# Altium schematic sheet Sheet2.SchDoc
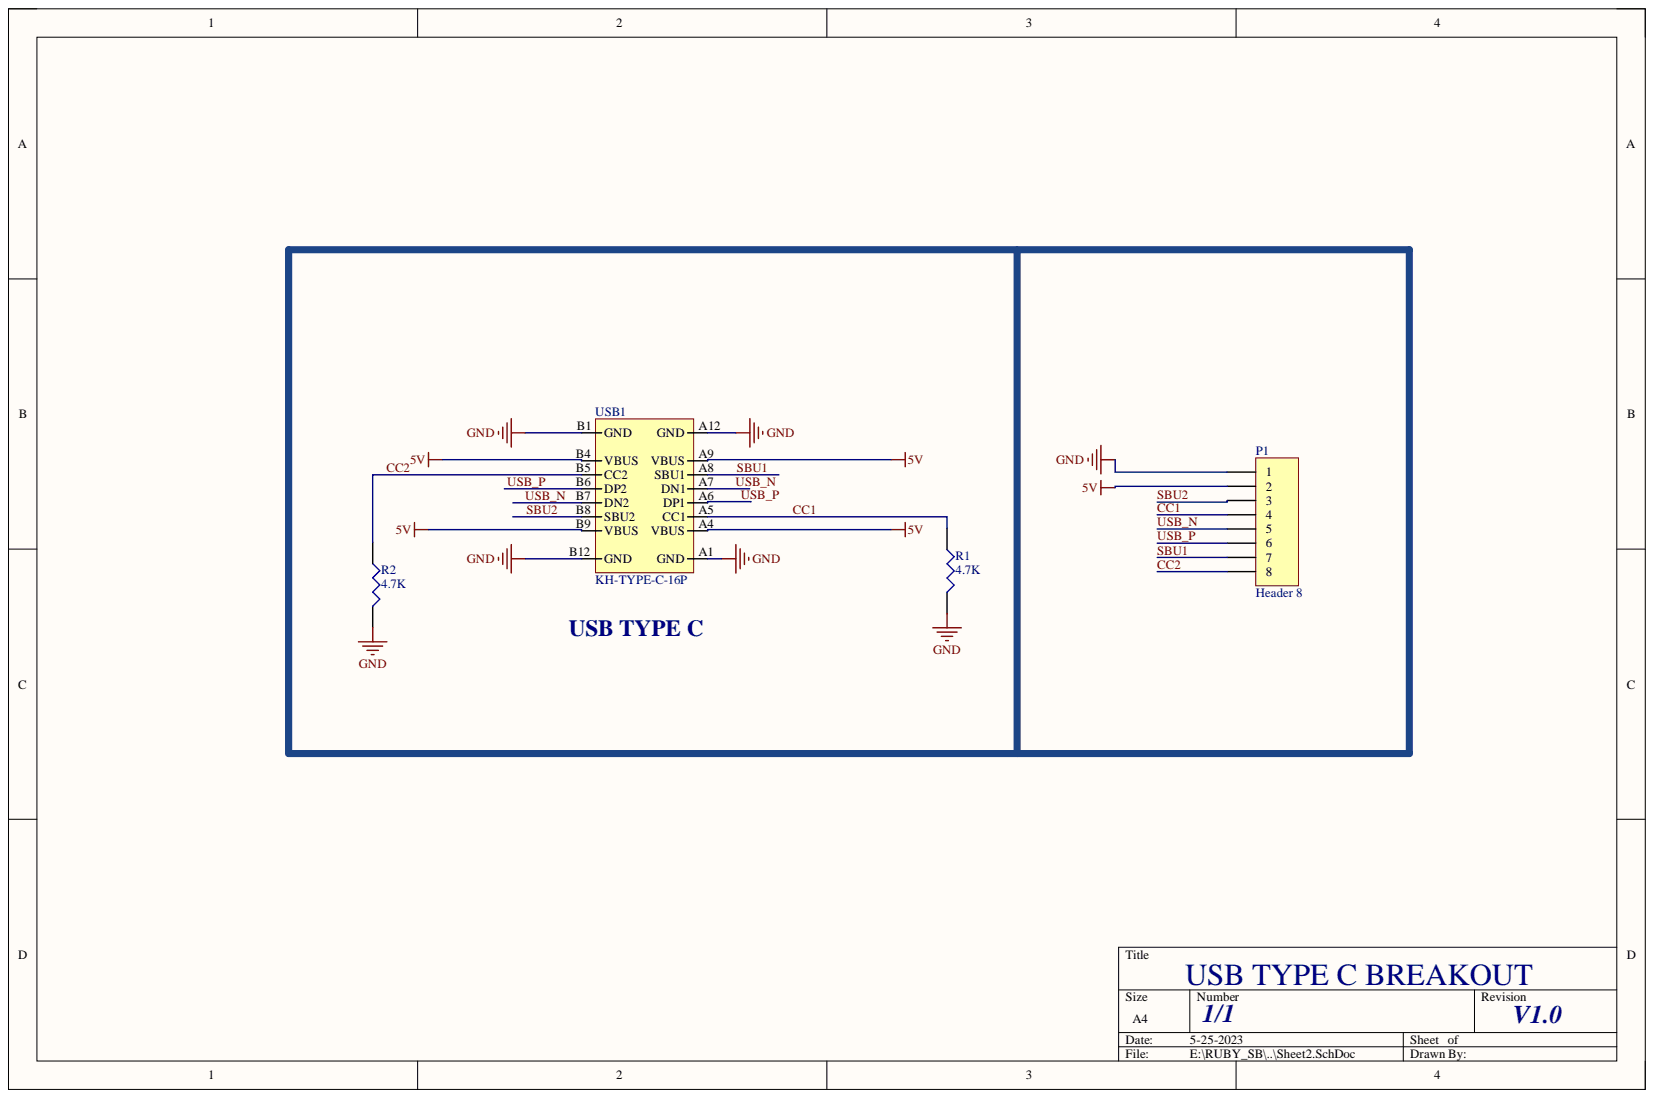
[diagram p0: Sheet2.SchDoc]

## Parts
P1  Header 8  [8P_BERG_2.54MM]
R1  4.7K  [R_0603]
R2  4.7K  [R_0603]
USB1  KH-TYPE-C-16P  [USBC-31-M-12]

## Nets
5V: P1.2 USB1.A4(VBUS) USB1.A9(VBUS) USB1.B4(VBUS) USB1.B9(VBUS)
GND: P1.1 R1.2 R2.2 USB1.A1(GND) USB1.A12(GND) USB1.B1(GND) USB1.B12(GND)
CC1: P1.4 R1.1 USB1.A5(CC1)
CC2: P1.8 R2.1 USB1.B5(CC2)
SBU1: P1.7 USB1.A8(SBU1)
SBU2: P1.3 USB1.B8(SBU2)
USB_N: P1.5 USB1.A7(DN1) USB1.B7(DN2)
USB_P: P1.6 USB1.A6(DP1) USB1.B6(DP2)

## Library data of the parts
P1 = Header 8: Header, 8-Pin; Component Kind: Standard; LatestRevisionDate: 17-Jul-2002; LatestRevisionNote: Re-released for DXP Platform.; Library Name: Miscellaneous Connectors.IntLib; Signal Integrity: Connector; Publisher: Altium Limited
R1 R2 = RES: Component Kind: Standard; Library Name: dxp_pasl_lib.IntLib
USB1 = USB TYPE-C KH-TYPE-C-16P: 3A 1 Surface Mount 16 Female -40?~+85? Type-C SMD USB Connectors ROHS #  C709357; Component Kind: Standard; ComponentLink2Description: New link; ComponentLink2URL: https://lcsc.com/product-detail/USB-Connectors_Shenzhen-Kinghelm-Elec-KH-TYPE-C-16P_C709357.html; Supplier 1: LCSC; Supplier Part Number 1: C165948; Library Name: dxp_pasl_lib.IntLib
